# Altium schematic sheet Power.SchDoc
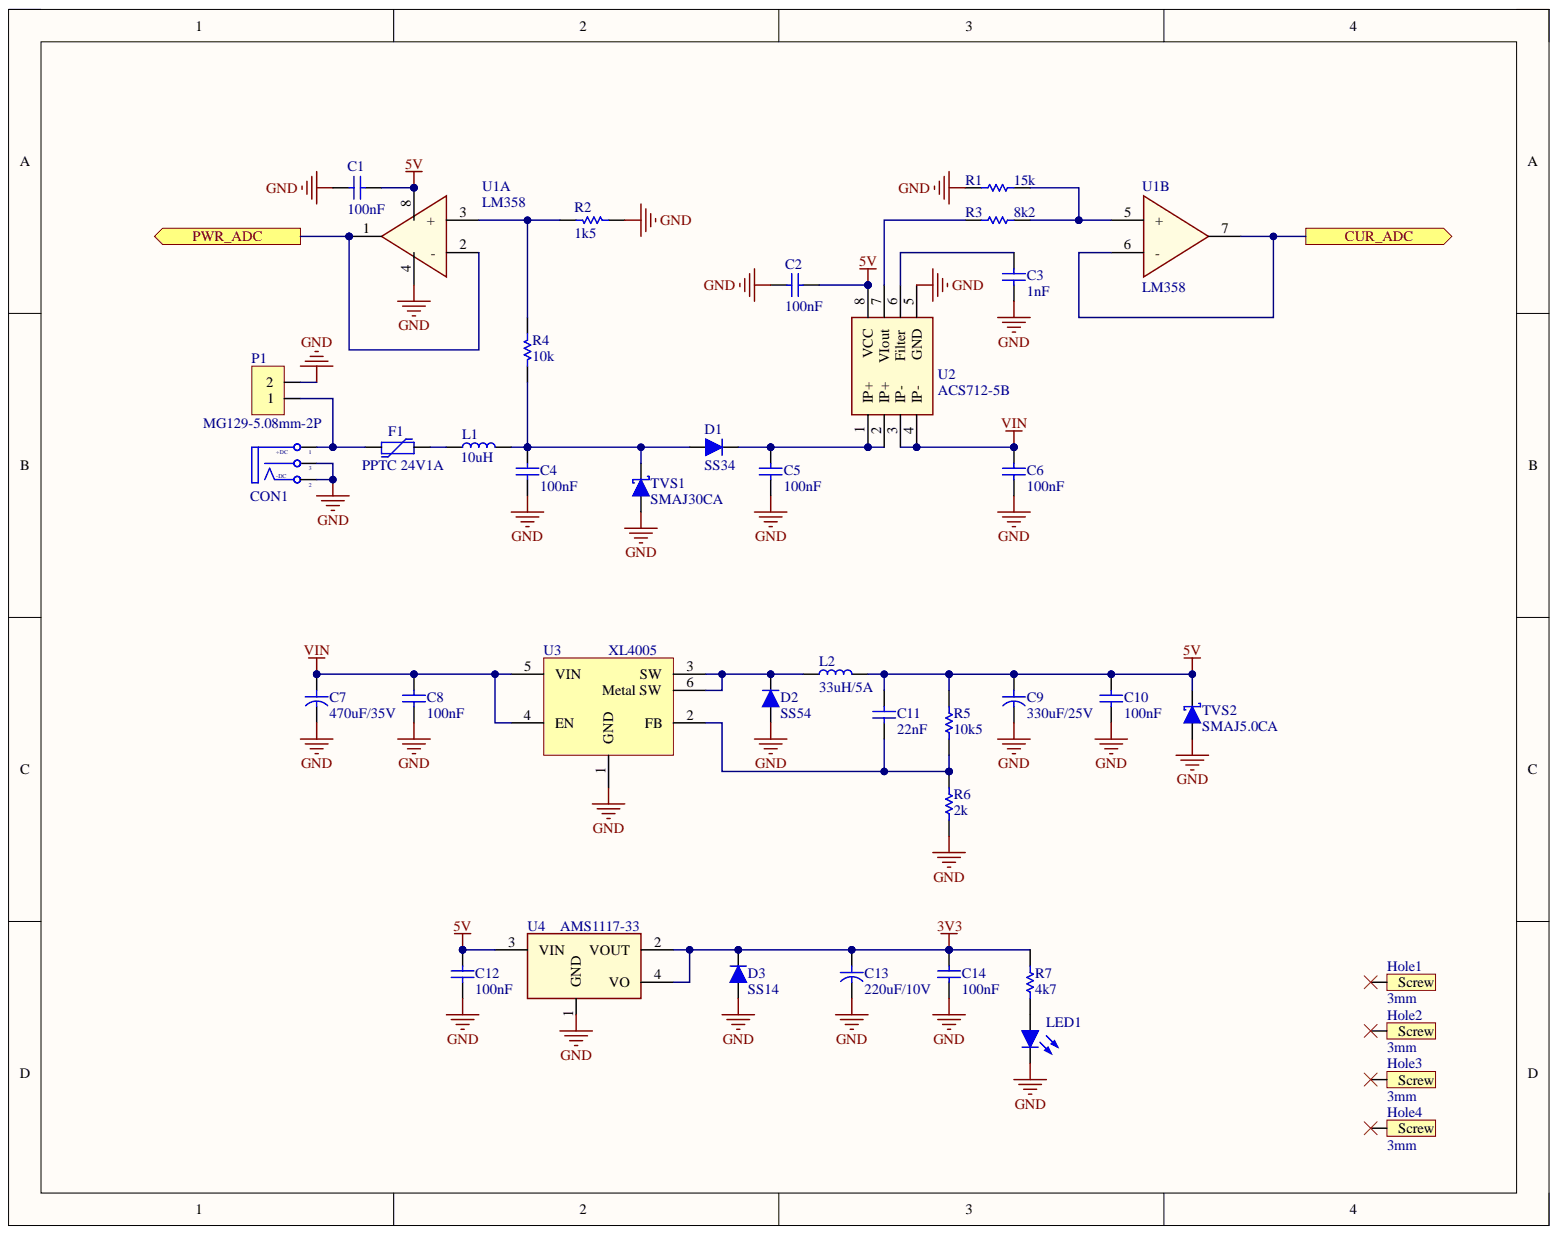
[diagram p0: Power.SchDoc]

## Parts
C1  100nF  [C 0603 (1608)]
C2  100nF  [C 0603 (1608)]
C3  1nF  [C 0603 (1608)]
C4  100nF  [C 0603 (1608)]
C5  100nF  [C 0603 (1608)]
C6  100nF  [C 0603 (1608)]
C7  470uF/35V  [C SMD ALU (G)]
C8  100nF  [C 0603 (1608)]
C9  330uF/25V  [C SMD ALU (F)]
C10  100nF  [C 0603 (1608)]
C11  22nF  [C 0603 (1608)]
C12  100nF  [C 0603 (1608)]
C13  220uF/10V  [C SMD ALU (D)]
C14  100nF  [C 0603 (1608)]
CON1  CON DC PWR  [CON DC-044]  (value not drawn)
D1  SS34  [DO-214AC (SMA)]
D2  SS54  [DO-214AC (SMA)]
D3  SS14  [DO-214AC (SMA)]
F1  PPTC 24V1A  [Fuse 1812P050]
Hole1  3mm  [SCREW/3M/7M]
Hole2  3mm  [SCREW/3M/7M]
Hole3  3mm  [SCREW/3M/7M]
Hole4  3mm  [SCREW/3M/7M]
L1  10uH  [Inductor SMD 0420]
L2  33uH/5A  [Inductor SMD 10x10x5]
LED1  Led-Red  [Led SMD 0603 RED]  (value not drawn)
P1  MG129-5.08mm-2P  [HDR Domino 2 - 5MM]
R1  15k  [R 0603 (1608)]
R2  1k5  [R 0603 (1608)]
R3  8k2  [R 0603 (1608)]
R4  10k  [R 0603 (1608)]
R5  10k5  [R 0603 (1608)]
R6  2k  [R 0603 (1608)]
R7  4k7  [R 0603 (1608)]
TVS1  SMAJ30CA  [DO-214AC (SMA)]
TVS2  SMAJ5.0CA  [DO-214AC (SMA)]
U1  LM358  [SOIC-8]  (parts A,B)
U2  ACS712-5B  [SOIC-8]
U3  XL4005  [TO-263-5]
U4  AMS1117-33  [SOT-223]

## Ports
CUR_ADC, PWR_ADC

## Nets
3V3: C13.1 C14.1 D3.2 R7.2 U4.2(VOUT) U4.4(VO)
5V: C1.1 C2.2 C9.1 C10.1 C11.1 C12.1 L2.2 R5.1 TVS2.2 U1.8 U2.8(VCC) U4.3(VIN)
GND: C1.2 C2.1 C3.1 C4.2 C5.2 C6.2 C7.2 C8.2 C9.2 C10.2 C12.2 C13.2 C14.2 CON1.2 CON1.3 D2.1 D3.1 LED1.2 P1.2 R1.1 R2.2 R6.2 TVS1.1 TVS2.1 U1.4 U2.5(GND) U3.1(GND) U3.6(Metal_SW) U4.1(GND)
VIN: C6.1 C7.1 C8.1 U2.3(IP-) U2.4(IP-) U3.4(EN) U3.5(VIN)
CUR_ADC: U1.6(-) U1.7 ; port CUR_ADC
PWR_ADC: U1.1 U1.2(-) ; port PWR_ADC
NetC3_2: C3.2 U2.6(Filter)
NetC4_1: C4.1 D1.1 L1.2 R4.1 TVS1.2
NetC5_1: C5.1 D1.2 U2.1(IP+) U2.2(IP+)
NetC11_2: C11.2 R5.2 R6.1 U3.2(FB)
NetCON1_1: CON1.1 F1.1 P1.1
NetD2_2: D2.2 L2.1 U3.3(SW) U3.6(Metal_SW)
NetF1_2: F1.2 L1.1
NetLED1_1: LED1.1 R7.1
NetR1_2: R1.2 R3.2 U1.5(+)
NetR2_1: R2.1 R4.2 U1.3(+)
NetR3_1: R3.1 U2.7(VIout)

Not connected: Hole1 1; Hole2 1; Hole3 1; Hole4 1

## Library data of the parts
C1-C6 C8 C10-C12 C14 = Capacitor NP: Capacitor non-pol; Component Kind: Standard; Library Name: BKIT4U_LIB.SchLib
C7 C9 C13 = Capacitor: Capacitor pol; Component Kind: Standard; Library Name: BKIT4U_LIB.SchLib
CON1 = CON DC PWR: DC input connector; Component Kind: Standard; Library Name: BKIT4U_LIB.SchLib
D1-D3 = Diode: Default Diode; Code_JEDEC: DO-214-AB; Component Kind: Standard; LatestRevisionDate: 17-Jul-2002; LatestRevisionNote: Re-released for DXP Platform.; Library Name: BKIT4U_LIB.SchLib; PackageReference: SMC; PackageVersion: Sep-1996; Published: 8-Jun-2000; Publisher: Altium Limited
F1 = PPTC Fuse: Component Kind: Standard; Library Name: BKIT4U_LIB.SchLib
Hole1-Hole4 = Screw: Component Kind: Standard; Library Name: BKIT4U_LIB.SchLib
L1 L2 = Inductor: Component Kind: Standard; Description: Inductor; Library Name: BKIT4U_LIB.SchLib
LED1 = Led: Typical LED; Component Kind: Standard; Library Name: BKIT4U_LIB.SchLib
P1 = Header 2: Header, 2-Pin; Component Kind: Standard; LatestRevisionDate: 17-Jul-2002; LatestRevisionNote: Re-released for DXP Platform.; Library Name: BKIT4U_LIB.SchLib; Publisher: Altium Limited
R1-R7 = Resistor: Component Kind: Standard; Description: Resistor; LatestRevisionDate: 17-Jul-2002; LatestRevisionNote: Re-released for DXP Platform.; Library Name: BKIT4U_LIB.SchLib; PackageReference: AXIAL-0.3; Published: 8-Jun-2000; Publisher: Altium Limited
TVS1 TVS2 = Diode Schottky: Schottky Diode; Code_JEDEC: DO-214-AA; Component Kind: Standard; LatestRevisionDate: 17-Jul-2002; LatestRevisionNote: Re-released for DXP Platform.; Library Name: BKIT4U_LIB.SchLib; PackageReference: SMB; Published: 8-Jun-2000; Publisher: Altium Limited
U1 = LM358: Low Power Dual Operational Amplifiers; Component Kind: Standard; Library Name: BKIT4U_LIB.SchLib
U2 = ACS712: Fully Integrated, Hall Effect-Based Linear Current Sensor IC   with 2.1 kVRMS Isolation and a Low-Resistance Current Conductor; Component Kind: Standard; Library Name: BKIT4U_LIB.SchLib
U3 = XL4005: 5A 300KHz 32V Buck DC to DC Converter; Component Kind: Standard; Library Name: BKIT4U_LIB.SchLib
U4 = AMS1117: 1A LDO Voltage Regulator, ADJ or fixed 1.5/1.8/2.5/2.85/3.3/5V; Component Kind: Standard; Library Name: BKIT4U_LIB.SchLib
